# Altium schematic sheet Sheet1.SchDoc
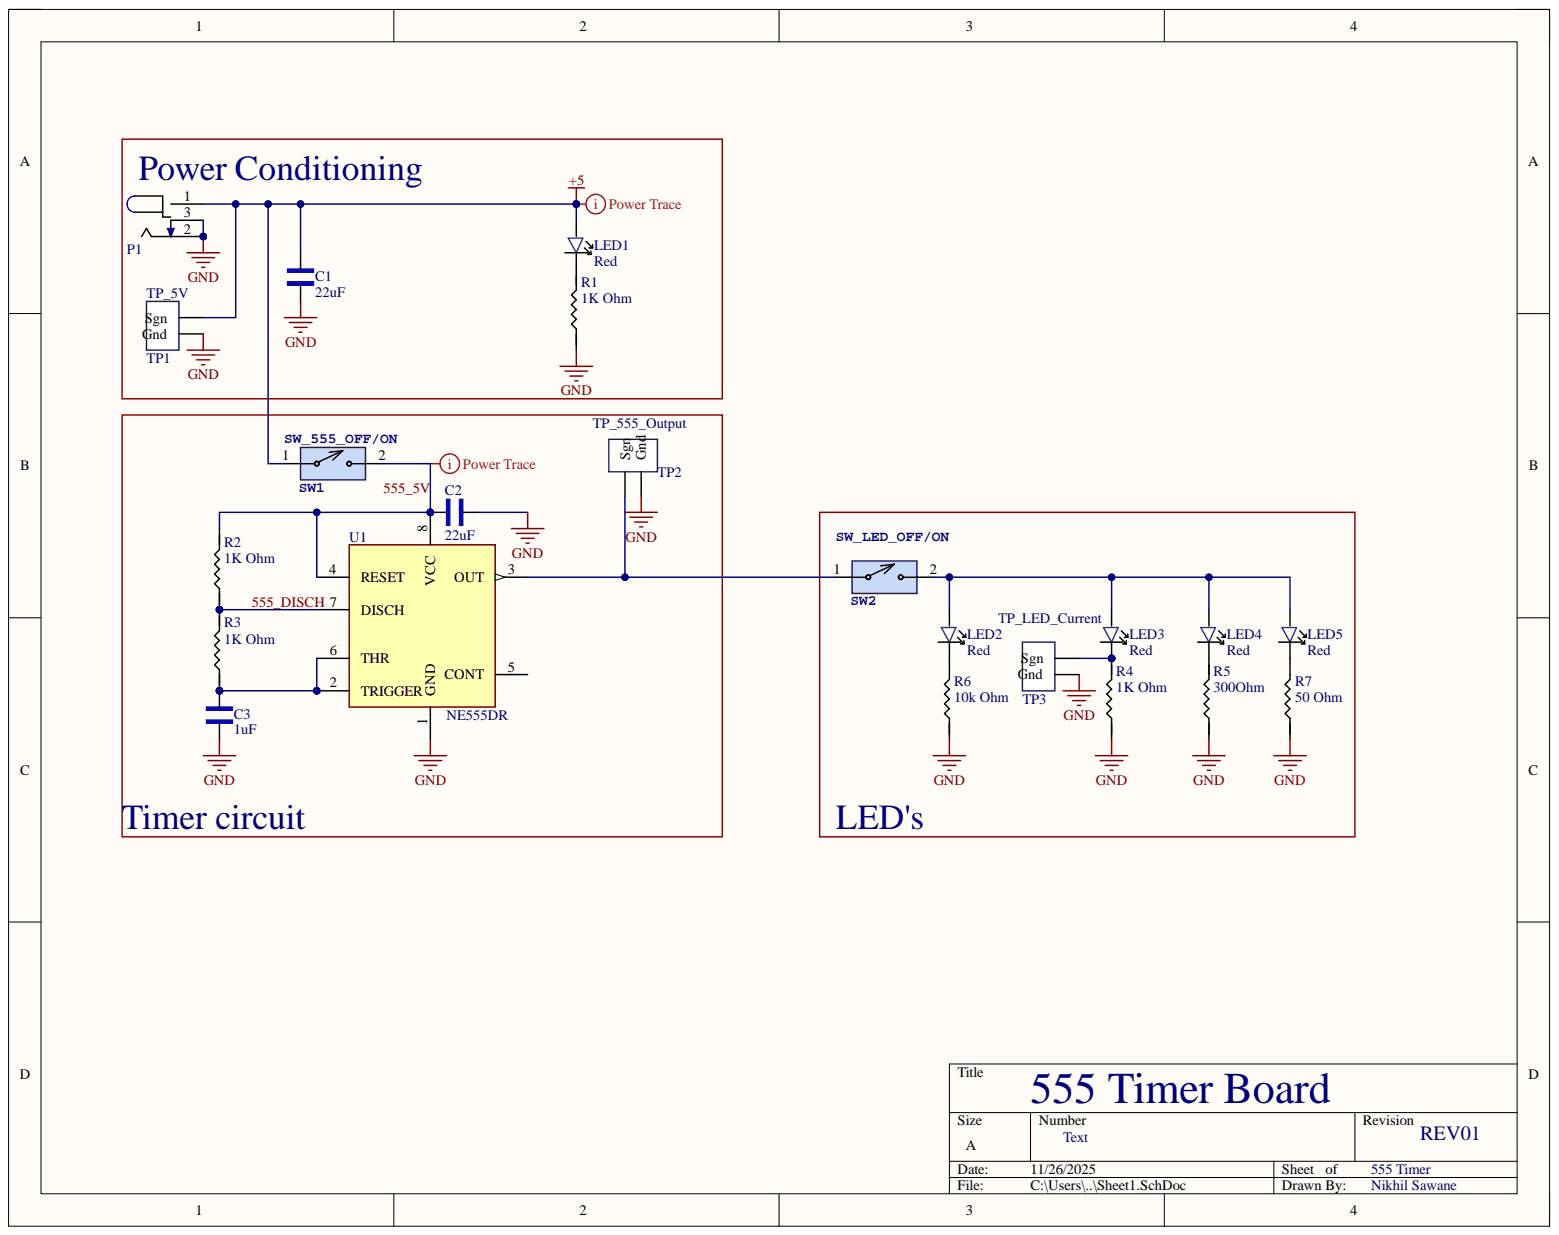
[diagram p0: Sheet1.SchDoc]

## Parts
C1  22uF  [1206_Passive_Capacitor]
C2  22uF  [1206_Passive_Capacitor]
C3  1uF  [1206_Passive_Capacitor]
LED1  Red  [LED_1206]
LED2  Red  [LED_1206]
LED3  Red  [LED_1206]
LED4  Red  [LED_1206]
LED5  Red  [LED_1206]
P1  Power Jack  [Power_Jack]  (value not drawn)
R1  value 1K Ohm  [1206_Passive_Resitor]  (hidden comment: 1k)
R2  value 1K Ohm  [1206_Passive_Resitor]  (hidden comment: 1k)
R3  value 1K Ohm  [1206_Passive_Resitor]  (hidden comment: 1k)
R4  value 1K Ohm  [1206_Passive_Resitor]  (hidden comment: 1k)
R5  300 Ohm  value 300Ohm  [1206_Passive_Resitor]
R6  10k Ohm  [1206_Passive_Resitor]
R7  50 Ohm  [1206_Passive_Resitor]
SW1  SW_555_OFF/ON  [2Pin-Header-Conn]
SW2  SW_LED_OFF/ON  [2Pin-Header-Conn]
TP1  TP_5V  [TP10x_Probe]
TP2  TP_555_Output  [TP10x_Probe]
TP3  TP_LED_Current  [TP10x_Probe]
U1  NE555DR  [TS555IDTTR]

## Nets
+5: C1.2 LED1.1 P1.1 SW1.1 TP1.1
GND: C1.1 C2.1 C3.1 P1.2 P1.3 R1.1 R4.1 R5.1 R6.1 R7.1 TP1.2 TP2.2 TP3.2 U1.1(GND)
555_5V: C2.2 R2.2 SW1.2 U1.4(RESET) U1.8(VCC)
555_DISCH: R2.1 R3.2 U1.7(DISCH)
NetC3_2: C3.2 R3.1 U1.2(TRIGGER) U1.6(THR)
NetLED1_2: LED1.2 R1.2
NetLED2_1: LED2.1 LED3.1 LED4.1 LED5.1 SW2.2
NetLED2_2: LED2.2 R6.2
NetLED3_2: LED3.2 R4.2 TP3.1
NetLED4_2: LED4.2 R5.2
NetLED5_2: LED5.2 R7.2
NetSW2_1: SW2.1 TP2.1 U1.3(OUT)

Not connected: U1 5(CONT)

## Library data of the parts
C1 C2 = C_22uF_1206: 22uF ±10% 25V X5R 1206 Multilayer Ceramic Capacitors MLCC - SMD/SMT RoHS; MFR Part #: CL31A226KAHNNNE; Type: basic; Component Kind: Standard; Datasheet: https://datasheet.lcsc.com/lcsc/1811031514_Samsung-Electro-Mechanics-CL31A226KAHNNNE_C12891.pdf; LCSC Part#: C12891; Library Name: ECEN5730Lib_2023_05_DS.IntLib; Value: 22uF
C3 = C_1uF_1206: MULTILAYER CERAMIC CAPACITORS MLCC - SMD/SMT 1UF 50V 1206 ROHS; MFR Part #: CL31B105KBHNNNE; Type: Basic; Component Kind: Standard; Digikey Link: https://www.digikey.com/product-detail/en/C1206C105M4RACTU/399-8150-1-ND/3471873/?itemSeq=304982366; LCSC Part#: C1848; Library Name: ECEN5730Lib_2023_05_DS.IntLib; Value: 1uF
LED1-LED5 = LED_RED_1206: Red 621~631nm 1206 Light Emitting Diodes (LED) RoHS; MFR Part #: 15-21/R6C-FQ1R1B/2T; Type: Extended; Component Kind: Standard; Datasheet: https://datasheet.lcsc.com/szlcsc/1811151241_Everlight-Elec-15-21-R6C-FQ1R1B-2T_C93133.pdf; LCSC Part#: C93133; Library Name: ECEN5730Lib_2023_05_DS.IntLib; Value: Red
P1 = P_Power Jack: Power Barrel Connector Jack 2.10mm ID (0.083"), 5.50mm OD (0.217") Through Hole, Right Angle; MFR Part #: PJ-102A; Value: CONN PWR JACK 2X5.5MM SOLDER; Component Kind: Standard; Digikey Link: https://www.digikey.com/product-detail/en/PJ-102A/CP-102A-ND/275425/?itemSeq=304982367; LCSC Part#: C136744; Library Name: ECEN5730Lib_2023_05_DS.IntLib; Type: Extended
R1-R4 = R_1K_1206: CHIP RESISTOR - SURFACE MOUNT 1KOHMS ±1% 1/4W 1206 ROHS; MFR Part #: RNCP1206FTD1K00CT; Component Kind: Standard; Digikey Link: https://www.digikey.com/product-detail/en/RNCP1206FTD1K00/RNCP1206FTD1K00CT-ND/2240675/?itemSeq=304982373; LCSC Part#: C4410; Library Name: ECEN5730Lib_2023_05_DS.IntLib; Type: Basic
R5 = R_300Ohm_1206: CHIP RESISTOR - SURFACE MOUNT 300OHMS ±1% 1/4W 1206 ROHS; MFR Part #: 1206W4F3000T5E; Component Kind: Standard; Datasheet: https://datasheet.lcsc.com/szlcsc/Uniroyal-Elec-1206W4F3000T5E_C17887.pdf; LCSC Part#: C17887; Library Name: ECEN5730Lib_2023_05_DS.IntLib; Type: Basic
R6 R7 = R_1M_1206: CHIP RESISTOR - SURFACE MOUNT 1MOHMS ±1% 1/4W 1206 ROHS; MFR Part #: RMCF1206JT1M00; Component Kind: Standard; Digikey Link: https://www.digikey.com/product-detail/en/RMCF1206JT1M00/RMCF1206JT1M00CT-ND/1942830/?itemSeq=304982358; LCSC Part#: C17927; Library Name: ECEN5730Lib_2023_05_DS.IntLib; Type: Basic
  R6: Value: 10k Ohm
  R7: Value: 50 Ohm
SW1 SW2 = SW_2Pin_100mil_Switch: 2Pin Header; Component Kind: Standard; LCSC Part#: C124375; Library Name: ECEN5730Lib_2023_05_DS.IntLib; Type: Extended
TP1-TP3 = TP_10x_Probe: Test Point 300 mil centers; Component Kind: Standard; Library Name: ECEN5730Lib_2023_05_DS.IntLib
U1 = U_555_Slow: 555 Timer SOIC-8_150MIL ROHS 150ns Rise Time; Component Kind: Standard; Digikey Link: https://www.digikey.com/product-detail/en/texas-instruments/NE555DR/296-6501-1-ND/372490; LCSC Part#: C7593; Library Name: ECEN5730Lib_2023_05_DS.IntLib; MFR Part #: NE555DR; Type: Basic
